# Altium schematic sheet EFR32_IO.SchDoc
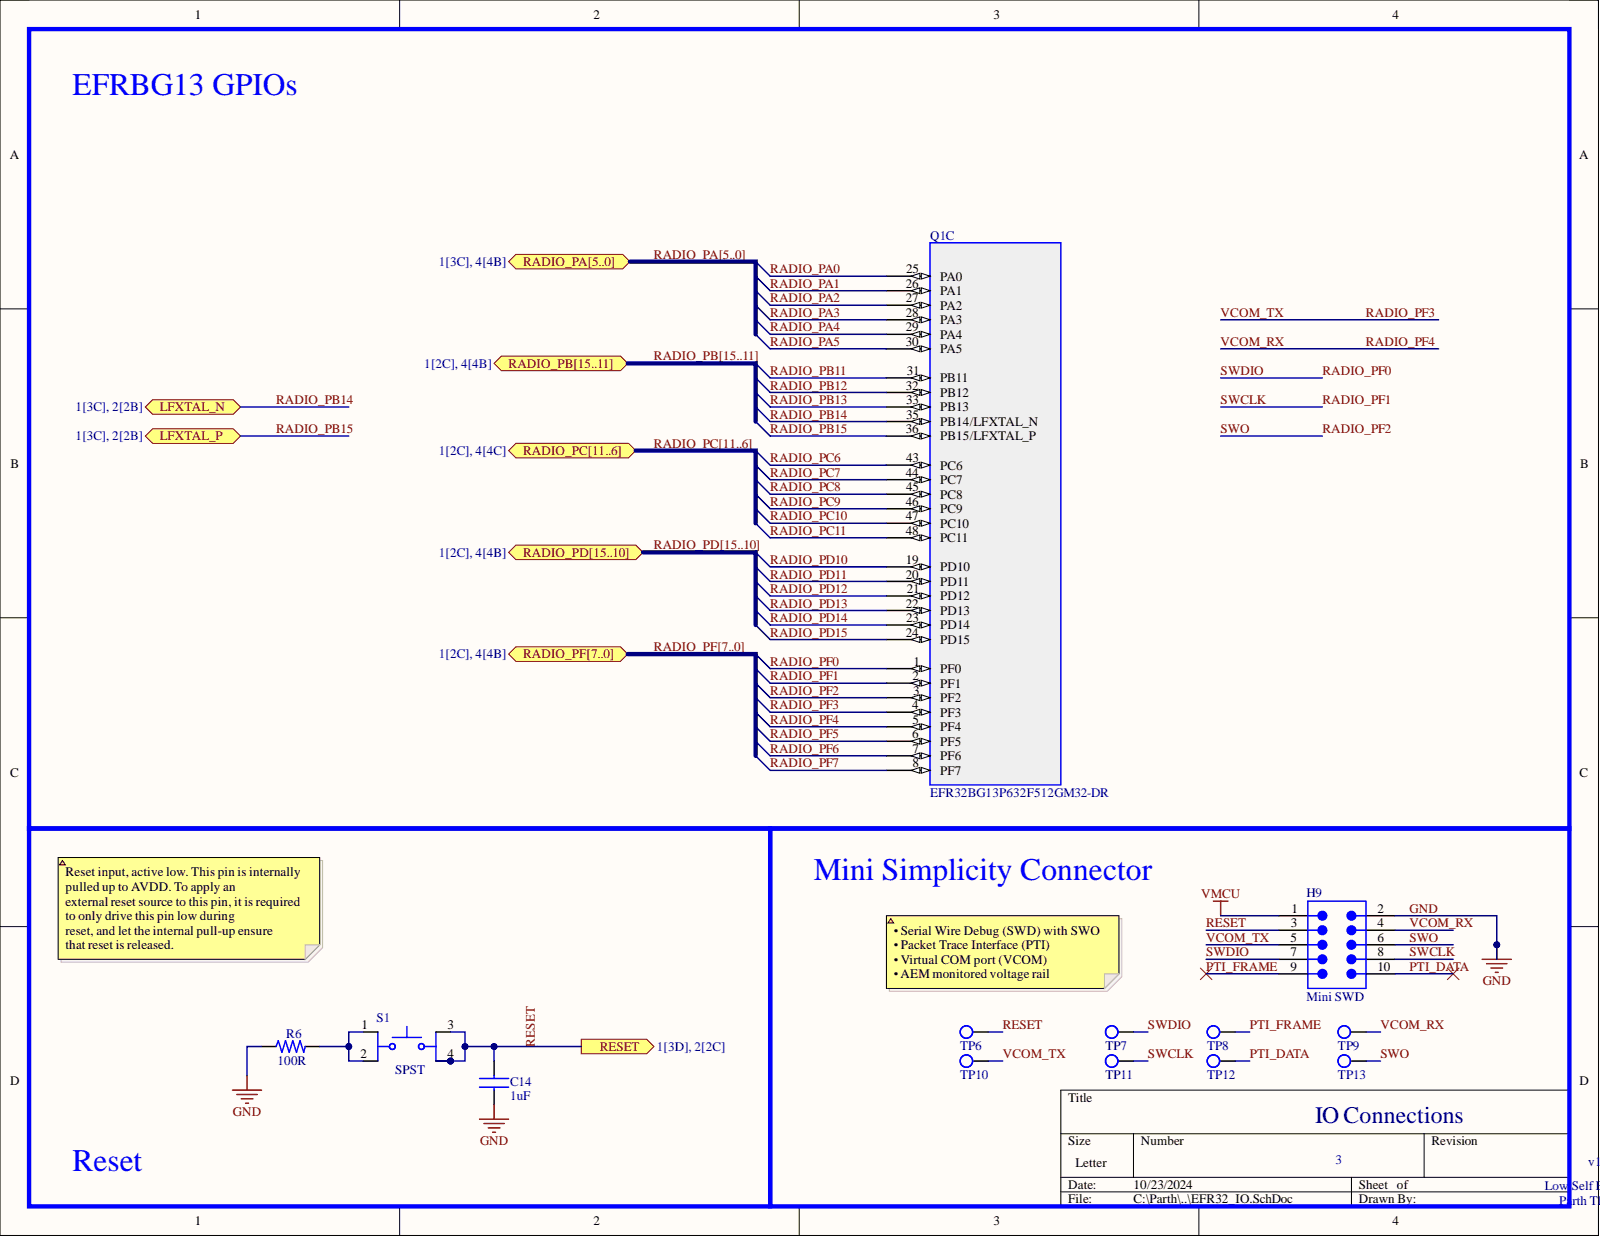
[diagram p0: EFR32_IO.SchDoc]

## Parts
C14  value 1uF  [Capacitor 0603]  (hidden comment: CAP 1uF 10V 0603)
H9  Mini SWD  [Mini Simplicity Connector]
Q1  EFR32BG13P632F512GM32-DR  [EFR32BG]  (part C)
R6  value 100R  [Resistor 0603]  (hidden comment: RES 100R 0603)
S1  SPST  [SPST]
TP6  Test point  [Test Point]  (value not drawn)  (no BOM)
TP7  Test point  [Test Point]  (value not drawn)  (no BOM)
TP8  Test point  [Test Point]  (value not drawn)  (no BOM)
TP9  Test point  [Test Point]  (value not drawn)  (no BOM)
TP10  Test point  [Test Point]  (value not drawn)  (no BOM)
TP11  Test point  [Test Point]  (value not drawn)  (no BOM)
TP12  Test point  [Test Point]  (value not drawn)  (no BOM)
TP13  Test point  [Test Point]  (value not drawn)  (no BOM)

## Ports
LFXTAL_N, LFXTAL_P, RADIO_PA0, RADIO_PA1, RADIO_PA2, RADIO_PA3, RADIO_PA4, RADIO_PA5, RADIO_PA[5..0], RADIO_PB11, RADIO_PB12, RADIO_PB13, RADIO_PB14, RADIO_PB15, RADIO_PB[15..11], RADIO_PC6, RADIO_PC7, RADIO_PC8, RADIO_PC9, RADIO_PC10, RADIO_PC11, RADIO_PC[11..6], RADIO_PD10, RADIO_PD11, RADIO_PD12, RADIO_PD13, RADIO_PD14, RADIO_PD15, RADIO_PD[15..10], RADIO_PF0, RADIO_PF1, RADIO_PF2, RADIO_PF3, RADIO_PF4, RADIO_PF5, RADIO_PF6, RADIO_PF7, RADIO_PF[7..0], RESET

## Nets
VMCU: H9.1
GND: C14.2 H9.2 R6.1
PTI_DATA: H9.10 TP12.1
PTI_FRAME: H9.9 TP8.1
RADIO_PA0: Q1.25(PA0) ; port RADIO_PA[5..0]  [also labelled RADIO_PA]
RADIO_PA1: Q1.26(PA1) ; port RADIO_PA[5..0]  [also labelled RADIO_PA]
RADIO_PA2: Q1.27(PA2) ; port RADIO_PA[5..0]  [also labelled RADIO_PA]
RADIO_PA3: Q1.28(PA3) ; port RADIO_PA[5..0]  [also labelled RADIO_PA]
RADIO_PA4: Q1.29(PA4) ; port RADIO_PA[5..0]  [also labelled RADIO_PA]
RADIO_PA5: Q1.30(PA5) ; port RADIO_PA[5..0]  [also labelled RADIO_PA]
RADIO_PB11: Q1.31(PB11) ; port RADIO_PB[15..11]  [also labelled RADIO_PB]
RADIO_PB12: Q1.32(PB12) ; port RADIO_PB[15..11]  [also labelled RADIO_PB]
RADIO_PB13: Q1.33(PB13) ; port RADIO_PB[15..11]  [also labelled RADIO_PB]
RADIO_PB14: Q1.35(PB14/LFXTAL_N) ; port LFXTAL_N, port RADIO_PB[15..11]  [also labelled RADIO_PB]
RADIO_PB15: Q1.36(PB15/LFXTAL_P) ; port LFXTAL_P, port RADIO_PB[15..11]  [also labelled RADIO_PB]
RADIO_PC6: Q1.43(PC6) ; port RADIO_PC[11..6]  [also labelled RADIO_PC]
RADIO_PC7: Q1.44(PC7) ; port RADIO_PC[11..6]  [also labelled RADIO_PC]
RADIO_PC8: Q1.45(PC8) ; port RADIO_PC[11..6]  [also labelled RADIO_PC]
RADIO_PC9: Q1.46(PC9) ; port RADIO_PC[11..6]  [also labelled RADIO_PC]
RADIO_PC10: Q1.47(PC10) ; port RADIO_PC[11..6]  [also labelled RADIO_PC]
RADIO_PC11: Q1.48(PC11) ; port RADIO_PC[11..6]  [also labelled RADIO_PC]
RADIO_PD10: Q1.19(PD10) ; port RADIO_PD[15..10]  [also labelled RADIO_PD]
RADIO_PD11: Q1.20(PD11) ; port RADIO_PD[15..10]  [also labelled RADIO_PD]
RADIO_PD12: Q1.21(PD12) ; port RADIO_PD[15..10]  [also labelled RADIO_PD]
RADIO_PD13: Q1.22(PD13) ; port RADIO_PD[15..10]  [also labelled RADIO_PD]
RADIO_PD14: Q1.23(PD14) ; port RADIO_PD[15..10]  [also labelled RADIO_PD]
RADIO_PD15: Q1.24(PD15) ; port RADIO_PD[15..10]  [also labelled RADIO_PD]
RADIO_PF0: H9.7 Q1.1(PF0) TP7.1 ; port RADIO_PF[7..0]  [also labelled RADIO_PF]
RADIO_PF1: H9.8 Q1.2(PF1) TP11.1 ; port RADIO_PF[7..0]  [also labelled RADIO_PF]
RADIO_PF2: H9.6 Q1.3(PF2) TP13.1 ; port RADIO_PF[7..0]  [also labelled RADIO_PF]
RADIO_PF3: H9.5 Q1.4(PF3) TP10.1 ; port RADIO_PF[7..0]  [also labelled RADIO_PF]
RADIO_PF4: H9.4 Q1.5(PF4) TP9.1 ; port RADIO_PF[7..0]  [also labelled RADIO_PF]
RADIO_PF5: Q1.6(PF5) ; port RADIO_PF[7..0]  [also labelled RADIO_PF]
RADIO_PF6: Q1.7(PF6) ; port RADIO_PF[7..0]  [also labelled RADIO_PF]
RADIO_PF7: Q1.8(PF7) ; port RADIO_PF[7..0]  [also labelled RADIO_PF]
RESET: C14.1 H9.3 S1.3 S1.4 TP6.1 ; port RESET
NetR6_2: R6.2 S1.1 S1.2

## Library data of the parts
C14 = CAP 1uF 10V 0603: Ceramic Capacitor, Multilayer, Ceramic, 10V, 10% +Tol, 10% -Tol, X5R, 15% TC, 1uF, Surface Mount, 0603; Manufacturer: Murata; Manufacturer Part Number: GRM188R61A105KA61D; Multilayer: Yes; Capacitor Type: Ceramic; Case/Package: 0603; Category: Ceramic Capacitors; Component Kind: Standard; ComponentLink1Description: https://psearch.en.murata.com:443/capacitor/product/GRM188R61A105KA61%23.pdf?src-supplier=IHS+Markit; ComponentLink1URL: https://psearch.en.murata.com:443/capacitor/product/GRM188R61A105KA61%23.pdf?src-supplier=IHS+Markit; ComponentLink2Description: https://datasheet.ciiva.com/18102/getdatasheetpartid-85467-18102910.pdf?src-supplier=Verical; ComponentLink2URL: https://datasheet.ciiva.com/18102/getdatasheetpartid-85467-18102910.pdf?src-supplier=Verical; ComponentLink3Description: https://datasheet.ciiva.com/19476/1857381-19476568.pdf?src-supplier=Jameco; ComponentLink3URL: https://datasheet.ciiva.com/19476/1857381-19476568.pdf?src-supplier=Jameco; ComponentLink4Description: https://datasheet.ciiva.com/7719/608985-7719036.pdf?src-supplier=Element14; ComponentLink4URL: https://datasheet.ciiva.com/7719/608985-7719036.pdf?src-supplier=Element14; ComponentLink5Description: https://datasheet.ciiva.com/22706/608985-22706782.pdf?src-supplier=Element14; ComponentLink5URL: https://datasheet.ciiva.com/22706/608985-22706782.pdf?src-supplier=Element14; ComponentLink6Description: https://datasheet.ciiva.com/131/partnumbering-e-01-131741.pdf?src-supplier=Digikey; ComponentLink6URL: https://datasheet.ciiva.com/131/partnumbering-e-01-131741.pdf?src-supplier=Digikey; ComponentLink7Description: https://datasheet.ciiva.com/131/partnumbering-e-01-131742.pdf?src-supplier=Digikey; ComponentLink7URL: https://datasheet.ciiva.com/131/partnumbering-e-01-131742.pdf?src-supplier=Digikey; ComponentLink8Description: https://datasheet.ciiva.com/131/partnumbering-e-01-131743.pdf?src-supplier=Digikey; ComponentLink8URL: https://datasheet.ciiva.com/131/partnumbering-e-01-131743.pdf?src-supplier=Digikey; ComponentLink9Description: https://datasheet.ciiva.com/16199/product-16199724.pdf?src-supplier=Future+Electronics; ComponentLink9URL: https://datasheet.ciiva.com/16199/product-16199724.pdf?src-supplier=Future+Electronics; Dielectric Material: Ceramic; Height: 0.8mm; JESD-609 Code: e3; Length: 1.6mm; Library Name: Keyboard_parts.SchLib; Max Operating Temperature: 85°C; Min Operating Temperature: -55°C; Mounting Technology: Surface Mount; Package Shape: Rectangular; Package Style: SMTMeter; Packaging: Tape and Reel; Pins: 2; Pricing: 1=0.1, 10=0.059, 50=0.0408, 100=0.0354, 500=0.0261, 1000=0.02321 (USD); Rated DC Voltage (URdc): 10V; Reach SVHC Compliant: Yes; RoHS: Yes; RoHS Compliant: Yes; Stock: 2506225; Supplier: Digikey; Supplier Part Number: 490-1543-1-ND; Temperature Characteristics Code: X5R; Terminal Finish: Nickel; Termination: Wraparound; Tolerance  (fill in as +-): 10%; Tolerance (fill in as +-): 10%; Width: 0.8mm
H9 = Debug Connector Mini SWD - 0.05": Mini SWD 0.05" Pitch Connector - 10 Pin SMT Box Header; Manufacturer: Adafruit Industries; Manufacturer Part Number: 4048; Category: Connectors; Component Kind: Standard; ComponentLink1Description: https://media.digikey.com/pdf/Data%20Sheets/Adafruit%20PDFs/4048_Web.pdf?src-supplier=Digi-Key; ComponentLink1URL: https://media.digikey.com/pdf/Data%20Sheets/Adafruit%20PDFs/4048_Web.pdf?src-supplier=Digi-Key; Library Name: Keyboard_parts.SchLib; Pricing 1: 1=1.95000 (USD); RoHS: No; Stock 1: 201; Supplier 1: Digi-Key
Q1 = EFR32BG13P632F512GM32-DR: BLue Gecko; Manufacturer: Silicon Labs; Category: RF Receivers, Transceivers; Component Kind: Standard; ComponentLink1Description: https://www.silabs.com/documents/login/data-sheets/efr32bg13-datasheet.pdf?src-supplier=Digikey; ComponentLink1URL: https://www.silabs.com/documents/login/data-sheets/efr32bg13-datasheet.pdf?src-supplier=Digikey; Library Name: Keyboard_parts.SchLib; Manufacturer Part Number: EFR32BG13P632F512GM32-DR; Pricing: 1=6.93, 10=6.355, 25=5.7772, 100=5.1995, 250=4.7662, 500=4.3329, 1000=3.89961 (USD); RoHS: No; RoHS Compliant: Yes; Stock: 30445; Supplier: Digikey; Supplier Part Number: 336-EFR32BG13P632F512GM32-DRCT-ND
R6 = RES 100R 0603: Fixed Resistor, Metal Glaze/thick Film, 0.1W, 100ohm, 75V, 1% +/-Tol, 100ppm/Cel, Surface Mount, 0603; Manufacturer: Yageo; Manufacturer Part Number: RC0603FR-07100RL; Additional Feature: LASER TRIMMABLE; Package Height: 0.45mm; Package Length: 1.6mm; Package Width: 0.8mm; Power: 100mW; Rated Temperature: 70°C; Temperature Coefficient: 100ppm/°C; Case/Package: 0603; Category: Chip SMD Resistors; Component Kind: Standard; ComponentLink1Description: https://datasheet.ciiva.com:443/pdfs/VipMasterIC/IC/YAGO/YAGO-S-A0008145938/YAGO-S-A0008145938-1.pdf?src-supplier=IHS+Markit; ComponentLink1URL: https://datasheet.ciiva.com:443/pdfs/VipMasterIC/IC/YAGO/YAGO-S-A0008145938/YAGO-S-A0008145938-1.pdf?src-supplier=IHS+Markit; Construction: Chip; JESD-609 Code: e3; Library Name: Keyboard_parts.SchLib; Max Operating Temperature: 155°C; Min Operating Temperature: -55°C; Mounting Technology: Surface Mount; Package Shape: Rectangular; Package Style: SMTMeter; Packaging: Tape and Reel; Pins: 2; Pricing: 1=0.1, 10=0.019, 50=0.0112, 100=0.0093, 500=0.00622, 1000=0.00532, 5000=0.00382, 10000=0.00335, 25000=0.00287, 35000=0.00278, 50000=0.00257, 125000=0.00232 (USD); Reach SVHC Compliant: Yes; Resistor Type: FIXED RESISTOR; RoHS: Yes; RoHS Compliant: Yes; Stock: 2134400; Supplier: Digikey; Supplier Part Number: 311-100HRCT-ND; Technology: METAL GLAZE/THICK FILM; Terminal Finish: Nickel; Termination: Wraparound; Tolerance: 1%; Working Voltage: 75V
S1 = SPST: Keypad Switch, 1 Switches, SPST, Momentary-tactile, 0.05A, 50VDC, 2.55N, Solder Terminal, Surface Mount-straight; Manufacturer: E-Switch; Manufacturer Part Number: TL3301NF260QG; Body Breadth: 6inch; Body Height: 3.6mm; Body Length or Diameter: 6mm; Circuit Configuration: 1X1; Contact Current(DC)-Max: 50mA; Contact DC Max Rating R Load: .05A@50VDC; Contact Voltage(DC)-Max: 50V; Number of Switches: 1; Operating Force-Max: 3.04N; Category: Tactile Switches; Component Kind: Standard; ComponentLink1Description: https://datasheet.ciiva.com:443/pdfs/VipMasterIC/IC/ESCH/ESCH-S-A0005350531/ESCH-S-A0005350531-1.pdf?src-supplier=IHS+Markit; ComponentLink1URL: https://datasheet.ciiva.com:443/pdfs/VipMasterIC/IC/ESCH/ESCH-S-A0005350531/ESCH-S-A0005350531-1.pdf?src-supplier=IHS+Markit; ComponentLink2Description: https://datasheet.ciiva.com/2901/p010517e-2901296.pdf?src-supplier=Digikey; ComponentLink2URL: https://datasheet.ciiva.com/2901/p010517e-2901296.pdf?src-supplier=Digikey; ComponentLink3Description: https://datasheet.ciiva.com/2901/tl3301-2901294.pdf?src-supplier=Digikey; ComponentLink3URL: https://datasheet.ciiva.com/2901/tl3301-2901294.pdf?src-supplier=Digikey; ComponentLink4Description: https://datasheet.ciiva.com/2901/tl3301-2901295.pdf?src-supplier=Digikey; ComponentLink4URL: https://datasheet.ciiva.com/2901/tl3301-2901295.pdf?src-supplier=Digikey; ComponentLink5Description: https://datasheet.ciiva.com/7637/tl3301-7637661.pdf?src-supplier=Element14; ComponentLink5URL: https://datasheet.ciiva.com/7637/tl3301-7637661.pdf?src-supplier=Element14; JESD-609 Code: e4; Library Name: Keyboard_parts.SchLib; Mounting Technology: Surface Mount; Packaging: Tape and Reel; Pricing: 1=0.42, 10=0.404, 25=0.3804, 100=0.3303, 250=0.29968, 500=0.27876 (USD); Reach SVHC Compliant: Yes; RoHS: Yes; RoHS Compliant: Yes; Stock: 45667; Supplier: Digikey; Supplier Part Number: EG1868CT-ND; Switch Action: MOMENTARY-TACTILE; Switch Function: SPST; Switch Type: Keypad; Terminal Finish: Silver; Termination: Solder
TP6-TP13 = Test point: Library Name: Keyboard_parts.SchLib
